# Altium schematic sheet Sheet2.SchDoc(Sheet2)
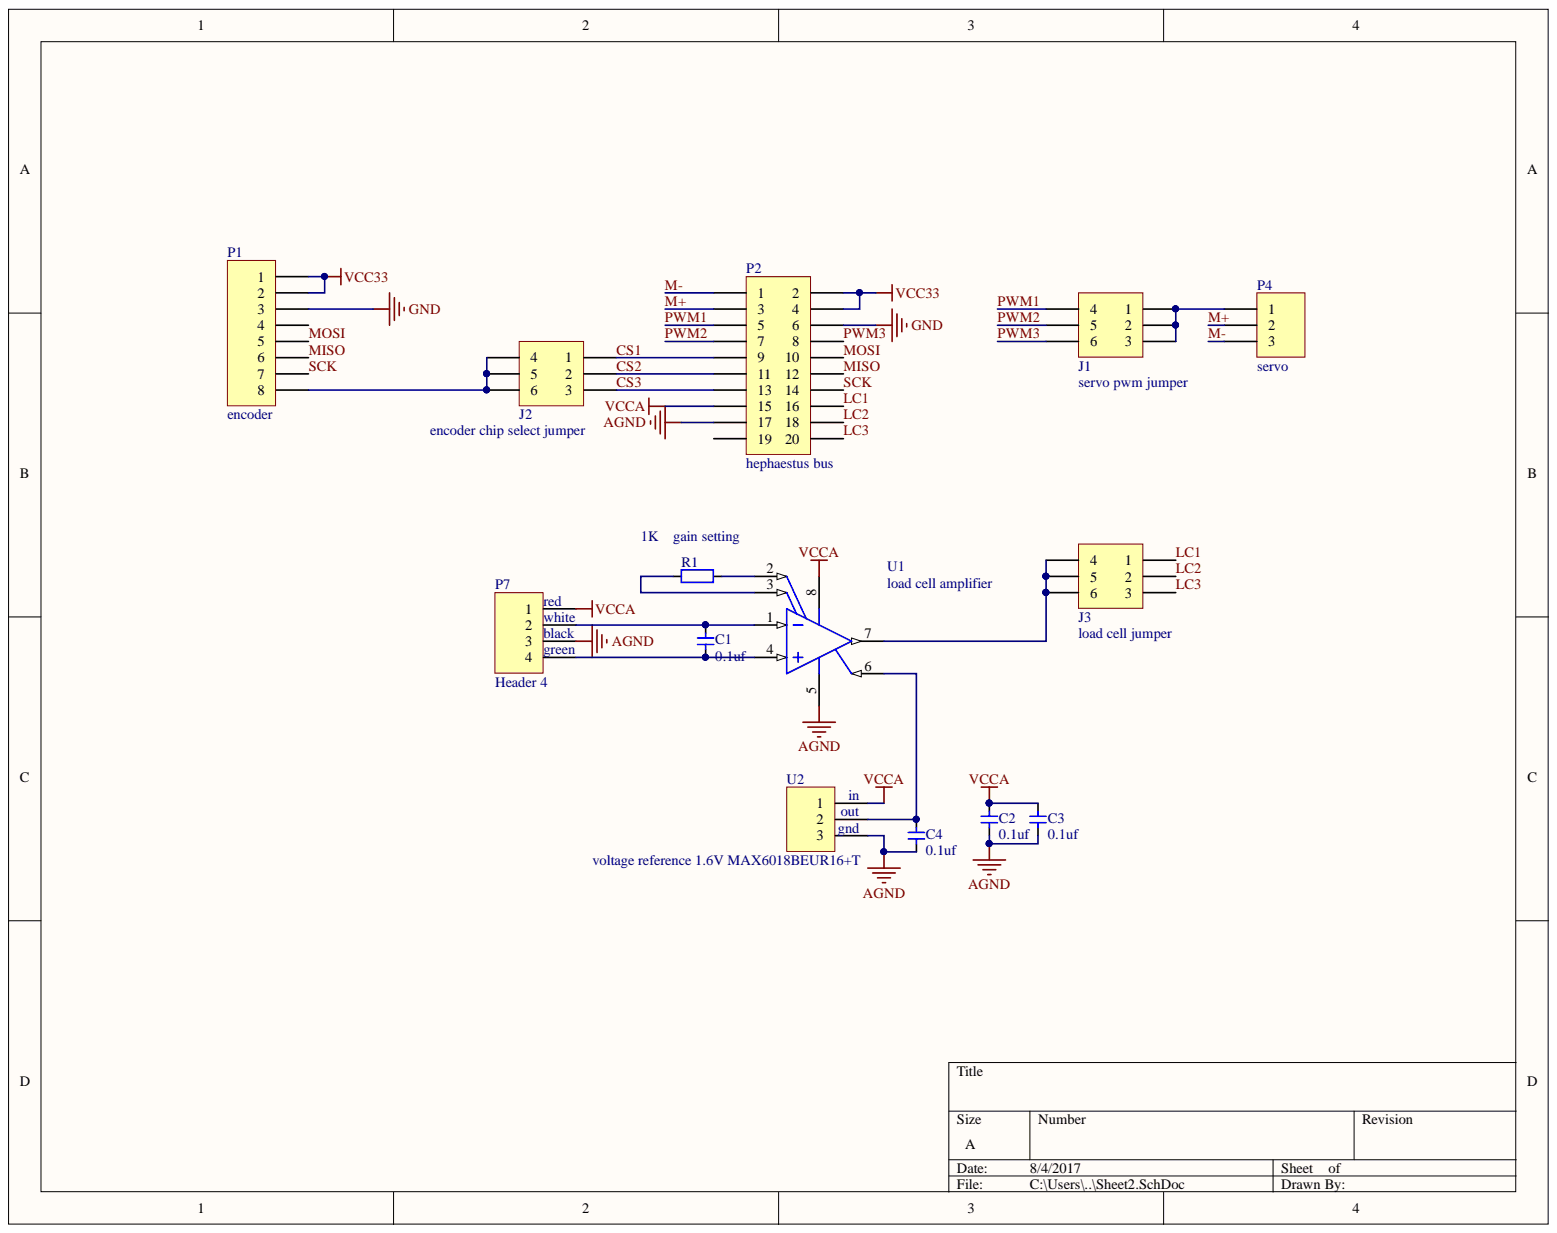
[diagram p0: Sheet2.SchDoc]

## Parts
C1  value 0.1uf  [CAP_0805]  (hidden comment: Cap_0805)
C2  value 0.1uf  [CAP_0805]  (hidden comment: Cap_0805)
C3  value 0.1uf  [CAP_0805]  (hidden comment: Cap_0805)
C4  value 0.1uf  [CAP_0805]  (hidden comment: Cap_0805)
J1  servo pwm jumper  [61300621121]
J2  encoder chip select jumper  [61300621121]
J3  load cell jumper  [61300621121]
P1  encoder  [HDR1X8]
P2  hephaestus bus  [612020235121]
P4  servo  [HDR1X3]
P7  Header 4  [HDR1X4]
R1  gain setting  value 1K  [RES_0805]
U1  load cell amplifier  [TI-D8_N]
U2  voltage reference 1.6V MAX6018BEUR16+T  [SOT23-3]

## Nets
AGND: C2.2 C3.2 C4.2 P2.17 P7.3 U1.5 U2.3
GND: P1.3 P2.6
VCC33: P1.1 P1.2 P2.2 P2.4
VCCA: C2.1 C3.1 P2.15 P7.1 U1.8 U2.1
CS1: J2.1 P2.9
CS2: J2.2 P2.11
CS3: J2.3 P2.13
LC1: J3.1 P2.16
LC2: J3.2 P2.18
LC3: J3.3 P2.20
M+: P2.3 P4.2
M-: P2.1 P4.3
MISO: P1.6 P2.12
MOSI: P1.5 P2.10
PWM1: J1.4 P2.5
PWM2: J1.5 P2.7
PWM3: J1.6 P2.8
SCK: P1.7 P2.14
NetC1_1: C1.1 P7.2 U1.1
NetC1_2: C1.2 P7.4 U1.4
NetC4_1: C4.1 U1.6 U2.2
NetJ1_1: J1.1 J1.2 J1.3 P4.1
NetJ2_4: J2.4 J2.5 J2.6 P1.8
NetJ3_4: J3.4 J3.5 J3.6 U1.7
NetR1_1: R1.1 U1.2
NetR1_2: R1.2 U1.3

Not connected: P1 4; P2 19

## Library data of the parts
C1-C4 = Cap_0805: Capacitor; Library Name: SCH_Passive.SchLib; Component Kind: Standard
J1-J3 = 61300621121: WR-PHD 2.54 mm THT Dual Pin Header, 06p; PublishedDate: July 2016; PinNumber: 06p; PartNumber: 61300621121; Library Name: ALTIUM-PHD_2_54_THT_PIN_HEADER_6130XX11121-rev16c.IntLib; ComponentLink2URL: http://katalog.we-online.de/em/datasheet/6130xx21121.pdf; ComponentLink2Description: Datasheet; ComponentLink1URL: http://www.we-online.de; ComponentLink1Description: Manufacturer; Component Kind: Standard; Publisher: Wurth Elektronik
P1 = Header 8: Header, 8-Pin; Signal Integrity: Connector; Library Name: Miscellaneous Connectors.IntLib; LatestRevisionNote: Re-released for DXP Platform.; LatestRevisionDate: 17-Jul-2002; Component Kind: Standard; Publisher: Altium Limited
P2 = 612020235121: WR-BHD 2.54mm Male Box Header High Temperature; Manufacturer: Wurth Elektronik; NoPins: 20; ComponentLink2 Description: Datasheet; ComponentLink1 Description: Manufacturer; partNo: 612020235121; Library Name: ALTIUM-BHD_2_54_MALE_BOX_HEADER_6120XX21621-rev16b.IntLib; Created Date: March 2016; ComponentLink2URL: http://katalog.we-online.de/em/datasheet/6120xx235121.pdf; ComponentLink1URL: http://www.we-online.com; Component Kind: Standard; Created by: Baojun Chen
P4 = Header 3: Header, 3-Pin; Signal Integrity: Connector; Library Name: Miscellaneous Connectors.IntLib; LatestRevisionNote: Re-released for DXP Platform.; LatestRevisionDate: 17-Jul-2002; Component Kind: Standard; Publisher: Altium Limited
P7 = Header 4: Header, 4-Pin; Signal Integrity: Connector; Library Name: Miscellaneous Connectors.IntLib; LatestRevisionNote: Re-released for DXP Platform.; LatestRevisionDate: 17-Jul-2002; Component Kind: Standard; Publisher: Altium Limited
R1 = Res_0805: Resistor; Library Name: SCH_Passive.SchLib; Component Kind: Standard
U1 = INA826AIDR: Precision, 200-uA Supply Current, 36-V Supply Instrumentation Amplifier, -40 to 125 degC, 8-pin SOIC (D8), Green (RoHS & no Sb/Br); Manufacturer: Texas Instruments; Vs(Min)(V): 2.7; Vs(Max)(V): 36; SubFamily: Single Supply; Quiescent Current (+/-)(Typ)(mA): 0.2; Output Offset Drift (+/-)(Max)(uV/Degrees Celsius): 10; Output Offset (+/-)(Max)(uV): 700; Number of Channels: 1; Non-Linearity (+/-)(Max)(%): 0.0005; Noise at 1kHz(Typ)(nV/rt(Hz)): 18; Input Offset Drift (+/-)(Max)(uV/Degrees Celsius): 2; Input Offset (+/-)(Max)(uV): 150; Input Bias Current (+/-)(Max)(nA): 65; Gain(V/V): 1 to 1000; CMRR(Min)(dB): 84; Supplier Part Number 5: 595-INA826AIDR; Supplier Part Number 4: 82T3832; Supplier Part Number 3: 296-30238-6-ND; Supplier Part Number 2: 296-30238-1-ND; Supplier Part Number 1: 296-30238-2-ND; Supplier 5: Mouser; Supplier 4: Newark; Supplier 3: Digi-Key; Supplier 2: Digi-Key; Supplier 1: Digi-Key; PartNumber: INA826AIDR; PackageVersion: 4040047-3/M, 06/2011; PackageReference: D8; PackageDescription: 8-Pin Plastic Small Outline, Body 4.9 x 3.9 mm, Pitch 1.27 mm; Mounting Technology: Surface Mount; Library Name: TI Amplifiers and Linear Instrumentation Amplifier.IntLib; Eco-Plan: Green (RoHS & no Sb/Br); DatasheetVersion: SBOS562E; ComponentLink3URL: http://www.ti.com/litv/pdf/msoi002j; ComponentLink3Description: Package Specification; ComponentLink2URL: http://www.ti.com/general/docs/lit/getliterature.tsp?genericPartNumber=INA826&fileType=pdf; ComponentLink2Description: Datasheet; ComponentLink1URL: http://www.ti.com/; ComponentLink1Description: Manufacturer URL; Component Kind: Standard; Code_JEDEC: MS-012
U2 = Header 3: MAX6018BEUR16+T; Library Name: Miscellaneous Connectors.IntLib; LatestRevisionNote: Re-released for DXP Platform.; LatestRevisionDate: 17-Jul-2002; Component Kind: Standard; Publisher: Altium Limited
